# Altium schematic sheet MAX31856 Sensors 2.SchDoc
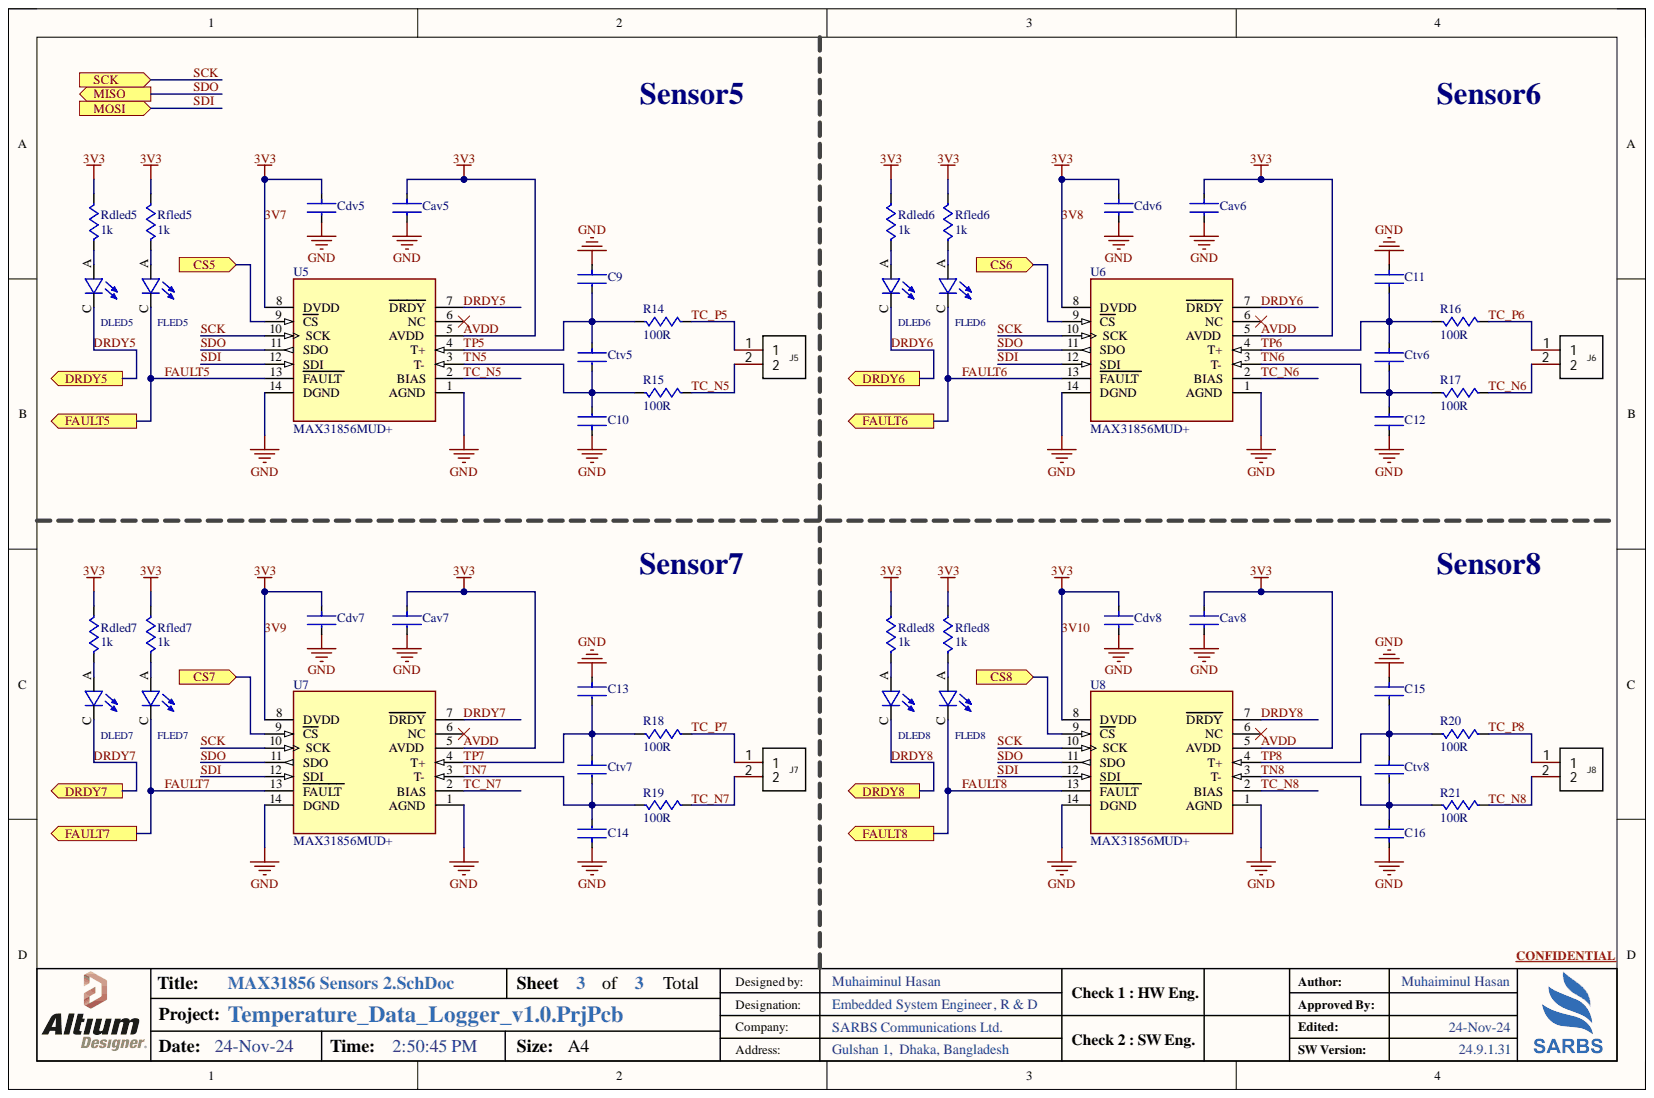
[diagram p0: MAX31856 Sensors 2.SchDoc]

## Parts
C9  (no value)  [0,1uF 25V cap TH CNC]
C10  (no value)  [0,1uF 25V cap TH CNC]
C11  (no value)  [0,1uF 25V cap TH CNC]
C12  (no value)  [0,1uF 25V cap TH CNC]
C13  (no value)  [0,1uF 25V cap TH CNC]
C14  (no value)  [0,1uF 25V cap TH CNC]
C15  (no value)  [0,1uF 25V cap TH CNC]
C16  (no value)  [0,1uF 25V cap TH CNC]
Cav5  (no value)  [0,1uF 25V cap TH CNC]
Cav6  (no value)  [0,1uF 25V cap TH CNC]
Cav7  (no value)  [0,1uF 25V cap TH CNC]
Cav8  (no value)  [0,1uF 25V cap TH CNC]
Cdv5  (no value)  [0,1uF 25V cap TH CNC]
Cdv6  (no value)  [0,1uF 25V cap TH CNC]
Cdv7  (no value)  [0,1uF 25V cap TH CNC]
Cdv8  (no value)  [0,1uF 25V cap TH CNC]
Ctv5  (no value)  [0,1uF 25V cap TH CNC]
Ctv6  (no value)  [0,1uF 25V cap TH CNC]
Ctv7  (no value)  [0,1uF 25V cap TH CNC]
Ctv8  (no value)  [0,1uF 25V cap TH CNC]
DLED5  L08R5000Q1  [LEDRD254W57D500H1070]  (value not drawn)
DLED6  L08R5000Q1  [LEDRD254W57D500H1070]  (value not drawn)
DLED7  L08R5000Q1  [LEDRD254W57D500H1070]  (value not drawn)
DLED8  L08R5000Q1  [LEDRD254W57D500H1070]  (value not drawn)
FLED5  L08R5000Q1  [LEDRD254W57D500H1070]  (value not drawn)
FLED6  L08R5000Q1  [LEDRD254W57D500H1070]  (value not drawn)
FLED7  L08R5000Q1  [LEDRD254W57D500H1070]  (value not drawn)
FLED8  L08R5000Q1  [LEDRD254W57D500H1070]  (value not drawn)
J5  WJ2EDGRC-5.08-2P  [Terminal Block P5.08-2P]  (value not drawn)
J6  WJ2EDGRC-5.08-2P  [Terminal Block P5.08-2P]  (value not drawn)
J7  WJ2EDGRC-5.08-2P  [Terminal Block P5.08-2P]  (value not drawn)
J8  WJ2EDGRC-5.08-2P  [Terminal Block P5.08-2P]  (value not drawn)
R14  100R  [RESA60-630X240]
R15  100R  [RESA60-630X240]
R16  100R  [RESA60-630X240]
R17  100R  [RESA60-630X240]
R18  100R  [RESA60-630X240]
R19  100R  [RESA60-630X240]
R20  100R  [RESA60-630X240]
R21  100R  [RESA60-630X240]
Rdled5  1k  [RESA60-630X240]
Rdled6  1k  [RESA60-630X240]
Rdled7  1k  [RESA60-630X240]
Rdled8  1k  [RESA60-630X240]
Rfled5  1k  [RESA60-630X240]
Rfled6  1k  [RESA60-630X240]
Rfled7  1k  [RESA60-630X240]
Rfled8  1k  [RESA60-630X240]
U5  MAX31856MUD+  [FP-U14-2-MFG]
U6  MAX31856MUD+  [FP-U14-2-MFG]
U7  MAX31856MUD+  [FP-U14-2-MFG]
U8  MAX31856MUD+  [FP-U14-2-MFG]

## Ports
CS5, CS6, CS7, CS8, DRDY5, DRDY6, DRDY7, DRDY8, FAULT5, FAULT6, FAULT7, FAULT8, MISO, MOSI, SCK

## Nets
GND: C9.1 C10.1 C11.1 C12.1 C13.1 C14.1 C15.1 C16.1 Cav5.2 Cav6.2 Cav7.2 Cav8.2 Cdv5.2 Cdv6.2 Cdv7.2 Cdv8.2 U5.1(AGND) U5.14(DGND) U6.1(AGND) U6.14(DGND) U7.1(AGND) U7.14(DGND) U8.1(AGND) U8.14(DGND)
3V7: Cav5.1 Cav6.1 Cav7.1 Cav8.1 Cdv5.1 Cdv6.1 Cdv7.1 Cdv8.1 Rdled5.2 Rdled6.2 Rdled7.2 Rdled8.2 Rfled5.2 Rfled6.2 Rfled7.2 Rfled8.2 U5.5(AVDD) U5.8(DVDD) U6.5(AVDD) U6.8(DVDD) U7.5(AVDD) U7.8(DVDD) U8.5(AVDD) U8.8(DVDD)
CS5: U5.9(CS) ; port CS5
CS6: U6.9(CS) ; port CS6
CS7: U7.9(CS) ; port CS7
CS8: U8.9(CS) ; port CS8
DRDY5: DLED5.C U5.7(DRDY) ; port DRDY5
DRDY6: DLED6.C U6.7(DRDY) ; port DRDY6
DRDY7: DLED7.C U7.7(DRDY) ; port DRDY7
DRDY8: DLED8.C U8.7(DRDY) ; port DRDY8
FAULT5: FLED5.C U5.13(FAULT) ; port FAULT5
FAULT6: FLED6.C U6.13(FAULT) ; port FAULT6
FAULT7: FLED7.C U7.13(FAULT) ; port FAULT7
FAULT8: FLED8.C U8.13(FAULT) ; port FAULT8
SCK: U5.10(SCK) U6.10(SCK) U7.10(SCK) U8.10(SCK) ; port SCK
SDI: U5.12(SDI) U6.12(SDI) U7.12(SDI) U8.12(SDI) ; port MOSI
SDO: U5.11(SDO) U6.11(SDO) U7.11(SDO) U8.11(SDO) ; port MISO
TC_N5: J5.2 R15.1 U5.2(BIAS)
TC_N6: J6.2 R17.1 U6.2(BIAS)
TC_N7: J7.2 R19.1 U7.2(BIAS)
TC_N8: J8.2 R21.1 U8.2(BIAS)
TC_P5: J5.1 R14.1
TC_P6: J6.1 R16.1
TC_P7: J7.1 R18.1
TC_P8: J8.1 R20.1
TN5: C10.2 Ctv5.2 R15.2 U5.3(T-)
TN6: C12.2 Ctv6.2 R17.2 U6.3(T-)
TN7: C14.2 Ctv7.2 R19.2 U7.3(T-)
TN8: C16.2 Ctv8.2 R21.2 U8.3(T-)
TP5: C9.2 Ctv5.1 R14.2 U5.4(T+)
TP6: C11.2 Ctv6.1 R16.2 U6.4(T+)
TP7: C13.2 Ctv7.1 R18.2 U7.4(t)
TP8: C15.2 Ctv8.1 R20.2 U8.4(T+)
NetDLED5_A: DLED5.A Rdled5.1
NetDLED6_A: DLED6.A Rdled6.1
NetDLED7_A: DLED7.A Rdled7.1
NetDLED8_A: DLED8.A Rdled8.1
NetFLED5_A: FLED5.A Rfled5.1
NetFLED6_A: FLED6.A Rfled6.1
NetFLED7_A: FLED7.A Rfled7.1
NetFLED8_A: FLED8.A Rfled8.1

Not connected: U5 6(NC); U6 6(NC); U7 6(NC); U8 6(NC)

## Library data of the parts
C9-C16 Cav5-Cav8 Cdv5-Cdv8 Ctv5-Ctv8 = CMP-06035-046728-1: Ceramic Capacitor 100nF ±10% 25V X7R Radial; Lead Diameter: 0.5mm; Capacitance: 100nF; Case/Package: Radial; Component Kind: Standard; Dielectric: X7R; Height: 3.5mm; Height - Seated (Max): 0.236inch; Lead Free: Lead Free; Lead Pitch: 0.098inch; Length: 0.197inch; Library Name: 0.1uF 25V cap cer TH.SchLib; Max Operating Temperature: 125°C; Min Operating Temperature: -55°C; Mount: Through Hole; Package Quantity: 2000; Packaging: Tape and Reel; Resistor Type: Ceramic; Termination: Radial; Tolerance: 10%; Voltage Rating: 25V; Voltage Rating (DC): 25V; Width: 3.5mm
DLED5-DLED8 FLED5-FLED8 = L08R5000Q1: LED, 5MM, ORANGE; LED / Lamp Size: 5mm / T-1 3/4; LED Colour: Orange; Typ Luminous Intensity: 4.3mcd; Viewing Angle: ...; MF: LED Technology; Availability: In Stock; Check_prices: https://www.snapeda.com/parts/L08R5000Q1/LED+Technology/view-part/?ref=eda; Component Kind: Standard; Library Name: LED 3mm.SchLib; MP: L08R5000Q1; Package: None; Price: None; SnapEDA_Link: https://www.snapeda.com/parts/L08R5000Q1/LED+Technology/view-part/?ref=snap
J5-J8 = WJ2EDGRC-5.08-2P: Manufacturer: KANGNEX(康奈克斯电气); Add into BOM: yes; Connector Type: 板端/Receptacle-Close; Number of PINs Per Row: 2; Pitch: 5.08mm; Structure: 1x2P; Color: Green; Component Kind: Standard; Convert to PCB: yes; Datasheet: https://atta.szlcsc.com/upload/public/pdf/source/20191225/C3697_6E7B45CD3FD3DA2CDF6A2CE707235E10.pdf; Device: WJ2EDGRC-5.08-2P; JLCPCB Part Class: Extended Part; LCSC Part Name: 5.08mm 1x2P 排数:1 每排P数:2; Library Name: Terminal Block P5.08-2P.SchLib; Manufacturer Part: WJ2EDGRC-5.08-2P; Mounting Style: Bend; Number of Pins: 2P; Number of Rows: 1; Supplier: LCSC; Supplier Footprint: 弯插,P=5.08mm; Supplier Part: C3697; Symbol: WJ2EDGRC-5.08-2P
R14-R21 Rdled5-Rdled8 Rfled5-Rfled8 = CMP-1659-00075-1: Axial Resistor,; Power Rating: 250 mW; Resistance: 1 kohm; Tolerance: 1%; Voltage Rating: 250 V; Case\Package: Axial; Component Kind: Standard; ComponentLink1Description: Manufacturer URL; ComponentLink1URL: http://www.yageo.com/; ComponentLink2Description: Datasheet; ComponentLink2URL: http://www.yageo.com/documents/recent/Yageo%20LR_MFR_2013.pdf; DatasheetVersion: Apr-13; Length: 0.248 inch; Library Name: Resistor Generic.SchLib; Max Operating Temperature: 155 degC; Min Operating Temperature: -55 degC; Package Quantity: 200; PackageDescription: 2-Pin Axial Lead, Dia 2.4 mm, Body Length 6.3 mm; PackageReference: MFR-25; Packaging: Bulk; RoHSCompliant: true
U5-U8 = CMP-05752-000010-1: IC CONV THERMOCOUPLE 14TSSOP; Interface: SPI; Max Supply Voltage: 3.6V; Min Supply Voltage: 3V; Operating Supply Current: 1.2mA; Output Type: Digital; Case/Package: TSSOP; Component Kind: Standard; Library Name: MAX31856MUD.SchLib; Max Operating Temperature: 125°C; Min Operating Temperature: -55°C; Mount: Surface Mount; Number of Pins: 14; Packaging: Rail/Tube; Supplier 1: Mouser; Supplier 2: Digikey; Supplier 3: Arrow Electronics; Supplier 4: Newark; Supplier 5: Farnell; Supplier Part Number 1: 700-MAX31856MUD+; Supplier Part Number 2: MAX31856MUD+-ND; Supplier Part Number 3: MAX31856MUD+; Supplier Part Number 4: 73Y3359; Supplier Part Number 5: 2515571
